# Altium schematic sheet 05_BT_TP_LCD_part.SchDoc
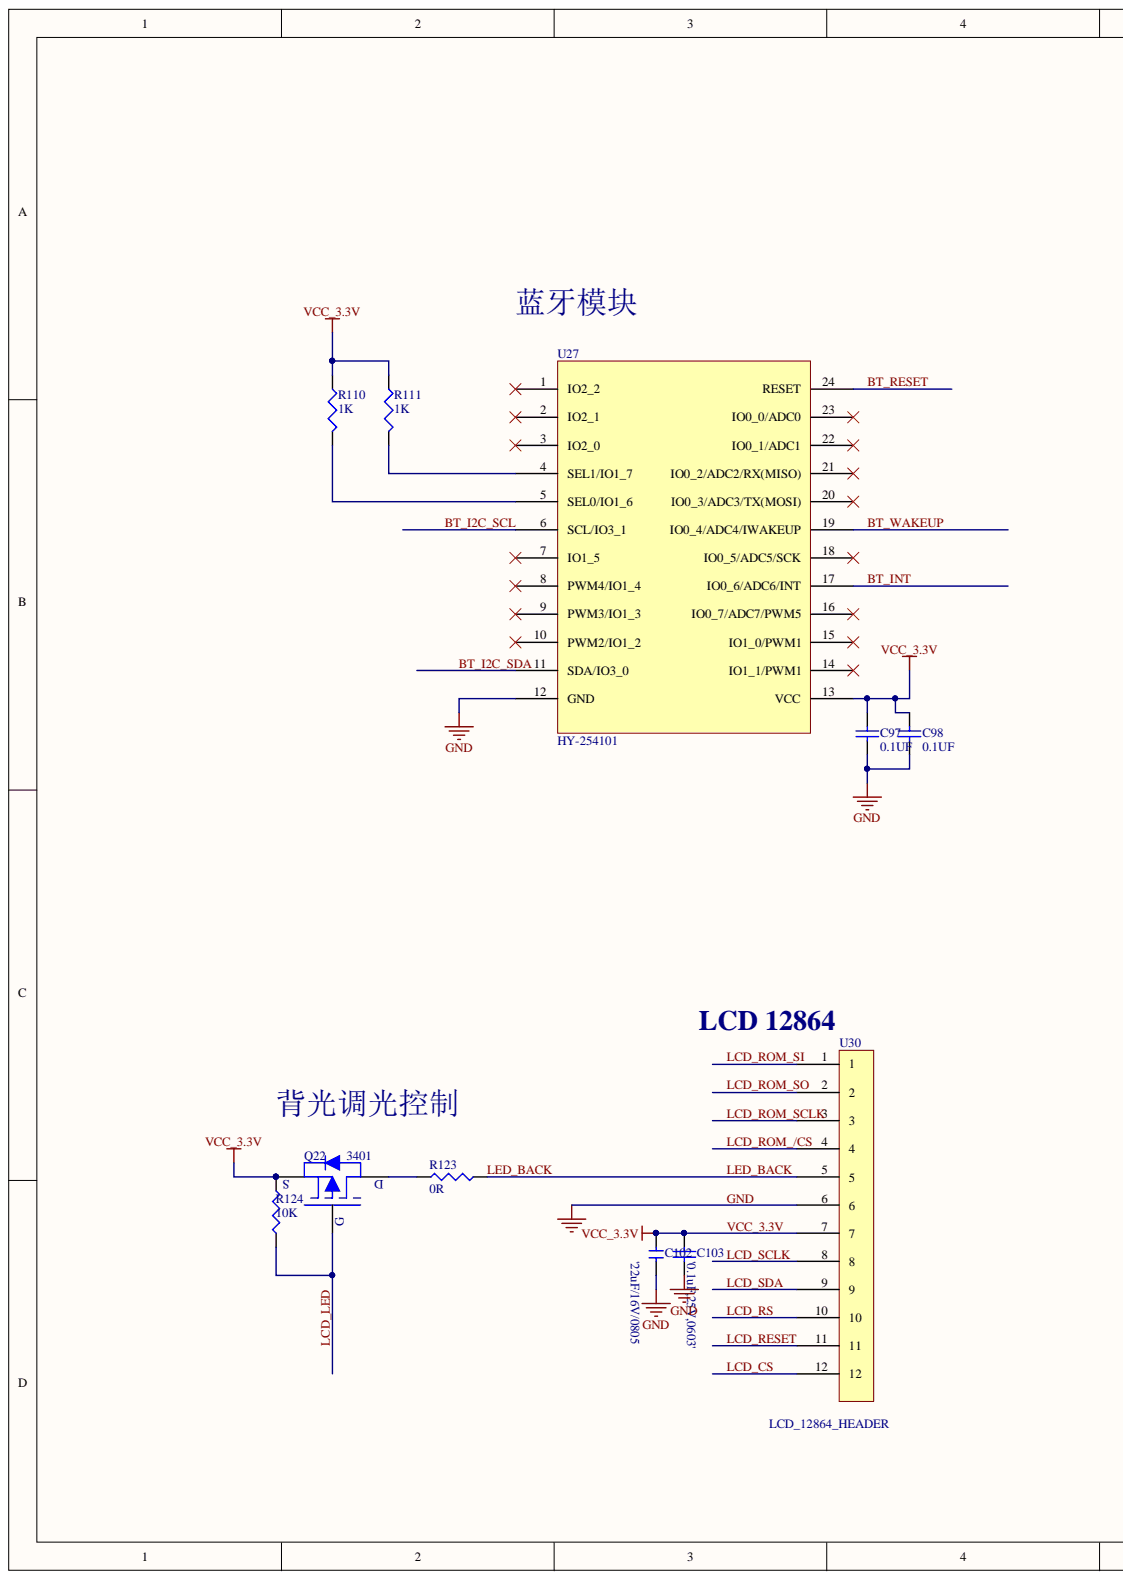
[diagram p0: 05_BT_TP_LCD_part.SchDoc, left]
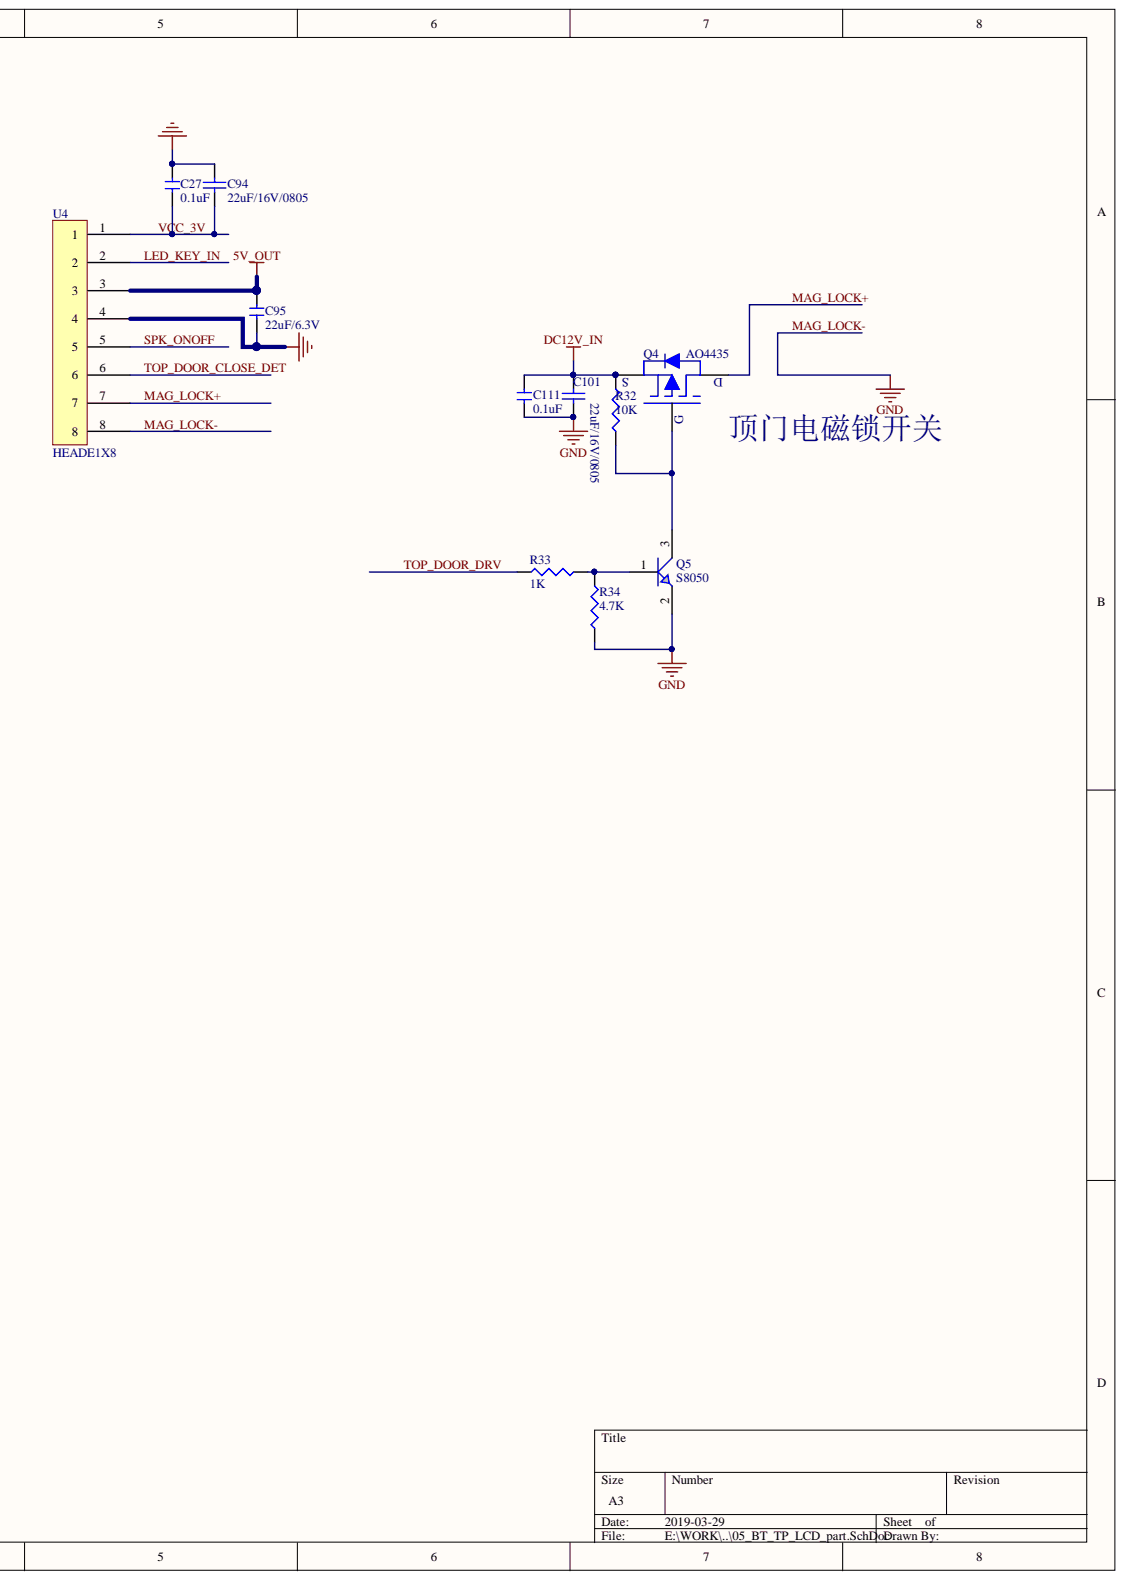
[diagram p1: 05_BT_TP_LCD_part.SchDoc, right]

## Parts
C27  0.1uF  [0603_C]
C94  22uF/16V/0805  [0805_C]
C95  22uF/6.3V  [0805_C]
C97  0.1UF  [0603_C]
C98  0.1UF  [0603_C]
C101  22uF/16V/0805  [1206_C]
C102  22uF  value '22uF/16V/0805  [0805_C]
C103  value '0.1uF,25V,0603'  [0603_C]  (hidden comment: 0.1uf)
C111  0.1uF  [0603_C]
Q4  AO4435  [SOT23]
Q5  S8050  [SOT23]
Q22  3401  [SOT23]
R32  10K  [0603_R]
R33  1K  [0603_R]
R34  4.7K  [0603_R]
R110  1K  [0603_R]
R111  1K  [0603_R]
R123  0R  [0603_R]
R124  10K  [0603_R]
U4  value HEADE1X8  [HEADER1X8_1.25MM-V]  (hidden comment: IC)
U27  HY-254101  [HY-254101]
U30  value LCD_12864_HEADER  [HEADER1X12_1.25MM-V]  (hidden comment: IC)

## Nets
5V_OUT: C95.2 U4.3
DC12V_IN: C101.1 C111.1 Q4.2 R32.2
BT_I2C_SCL: U27.6(SCL/IO3_1)
BT_I2C_SDA: U27.11(SDA/IO3_0)
BT_INT: U27.17(IO0_6/ADC6/INT)
BT_RESET: U27.24(RESET)
BT_WAKEUP: U27.19(IO0_4/ADC4/IWAKEUP)
GND: C27.1 C94.1 C95.1 C97.1 C98.1 C101.2 C102.1 C103.1 C111.2 Q5.2 R34.1 U4.4 U4.8 U27.12(GND) U30.6
LCD_CS: U30.12
LCD_LED: Q22.1 R124.1
LCD_RESET: U30.11
LCD_ROM_/CS: U30.4
LCD_ROM_SCLK: U30.3
LCD_ROM_SI: U30.1
LCD_ROM_SO: U30.2
LCD_RS: U30.10
LCD_SCLK: U30.8
LCD_SDA: U30.9
LED_BACK: R123.1 U30.5
LED_KEY_IN: U4.2
MAG_LOCK+: Q4.3 U4.7
SPK_ONOFF: U4.5
TOP_DOOR_CLOSE_DET: U4.6
TOP_DOOR_DRV: R33.1
VCC_3.3V: C97.2 C98.2 C102.2 C103.2 Q22.2 R110.1 R111.1 R124.2 U27.13(VCC) U30.7
VCC_3V: C27.2 C94.2 U4.1
NetQ4_1: Q4.1 Q5.3 R32.1
NetQ5_1: Q5.1 R33.2 R34.2
NetQ22_3: Q22.3 R123.2
NetR110_2: R110.2 U27.5(SEL0/IO1_6)
NetR111_2: R111.2 U27.4(SEL1/IO1_7)

Not connected: U27 1(IO2_2) 2(IO2_1) 3(IO2_0) 7(IO1_5) 8(PWM4/IO1_4) 9(PWM3/IO1_3) 10(PWM2/IO1_2) 14(IO1_1/PWM1) 15(IO1_0/PWM1) 16(IO0_7/ADC7/PWM5) 18(IO0_5/ADC5/SCK) 20(IO0_3/ADC3/TX(MOSI)) 21(IO0_2/ADC2/RX(MISO)) 22(IO0_1/ADC1) 23(IO0_0/ADC0)

## Library data of the parts
C27 C95 C102 C111 = Cap: Component Kind: Standard; Library Name: Celestial.SchLib; Type: Cap
C94 C97 C98 C101 = Cap Semi: Capacitor (Semiconductor SIM Model); Component Kind: Standard; LatestRevisionDate: 17-Jul-2002; LatestRevisionNote: Re-released for DXP Platform.; PackageReference: C1206; Published: 8-Jun-2000; Publisher: Altium Limited
  C94 C101: Value: 22uF/16V/0805
  C97 C98: Value: 0.1UF
C103 = Cap: Capacitor; Component Kind: Standard; LatestRevisionDate: 17-Jul-2002; LatestRevisionNote: Re-released for DXP Platform.; Library Name: Miscellaneous Devices.IntLib; PackageReference: RAD-0.3; Published: 8-Jun-2000; Publisher: Altium Limited
Q4 Q22 = PMOS_SOT23: Type: MOS; Component Kind: Standard; Library Name: Celestial.SchLib
R32-R34 R123 R124 = Res: Component Kind: Standard; Library Name: Celestial.SchLib; Type: Res
R110 R111 = Res3: Resistor; Component Kind: Standard; LatestRevisionDate: 17-Jul-2002; LatestRevisionNote: Re-released for DXP Platform.; Published: 8-Jun-2000; Value: 1K; Publisher: Altium Limited
U4 = HEADE1X8: Component Kind: Standard; Library Name: Jackson SCH.LIB
U30 = HEADE1X12: Component Kind: Standard; Library Name: Jackson SCH.LIB
Q5 = S8050: Component Kind: Standard; Value: S8050; Library Name: Jackson SCH.LIB
U27 = HY-254101: Component Kind: Standard; Value: HY-254101; Library Name: Jackson SCH.LIB
